# Altium schematic sheet Offset Compensator.SchDoc
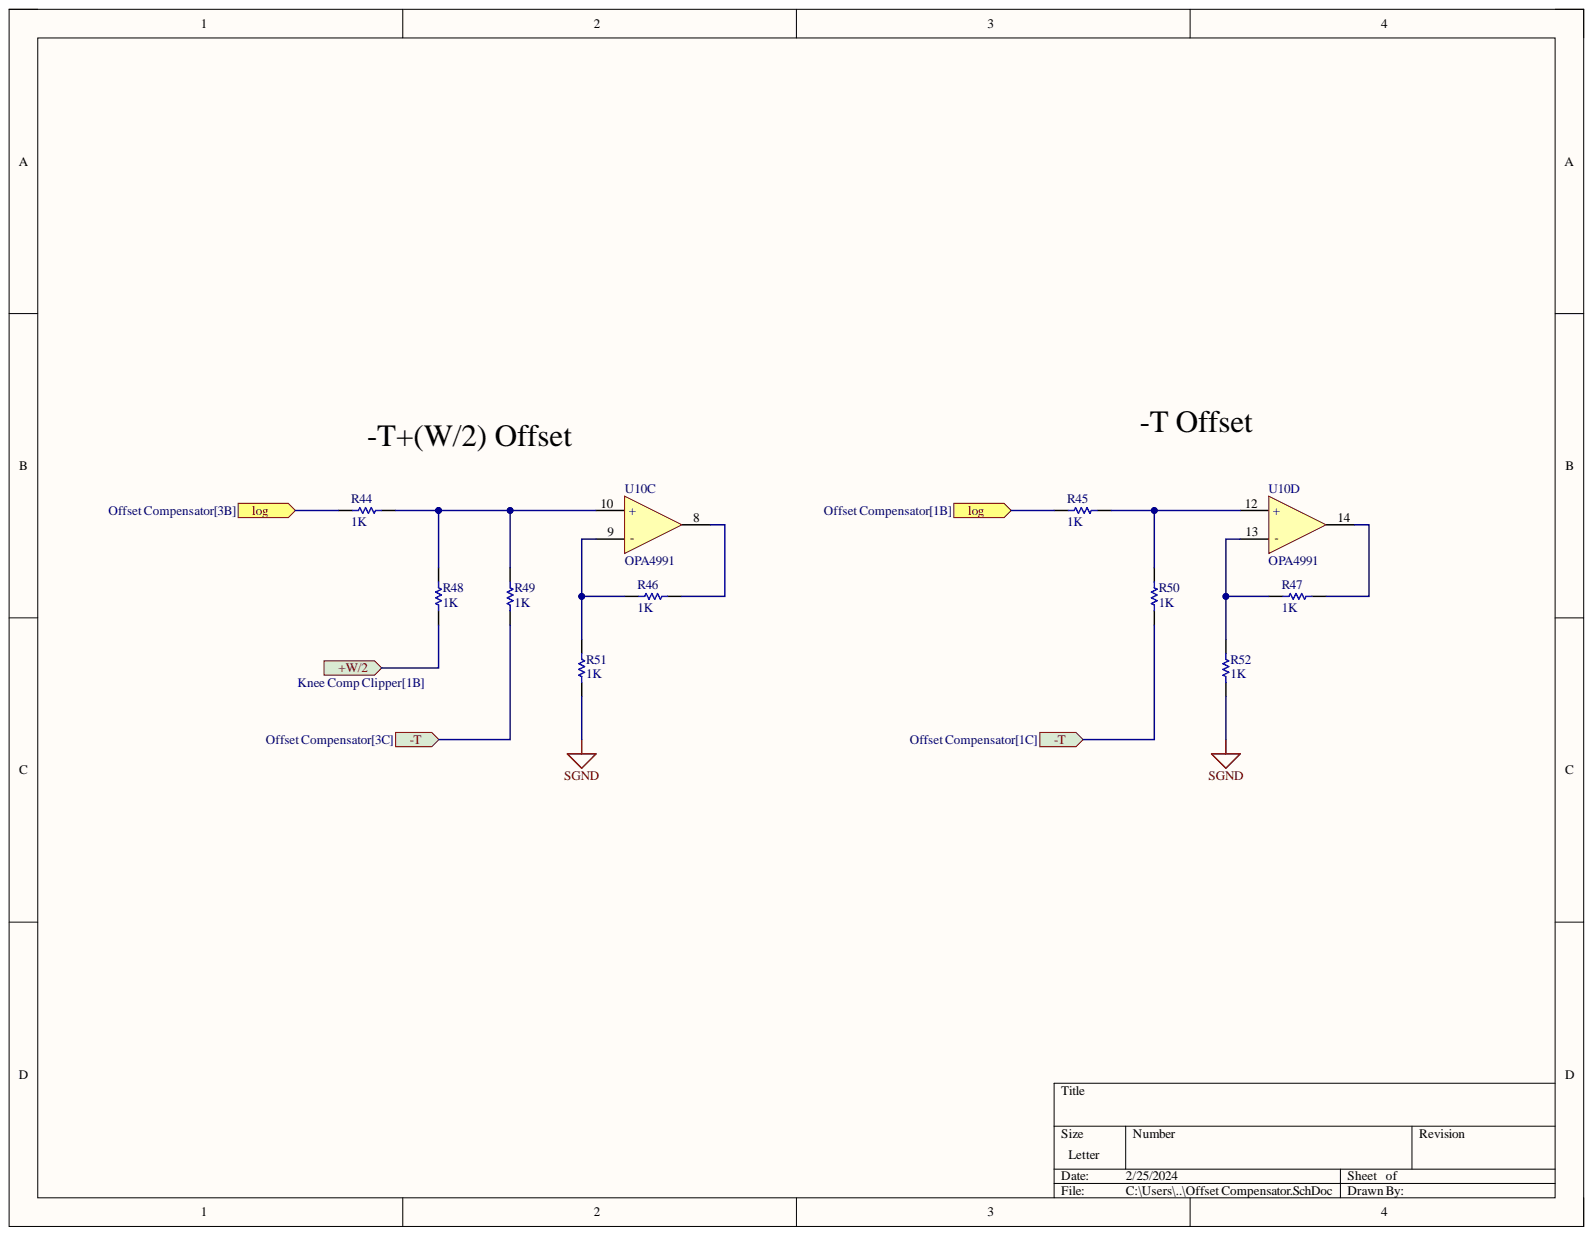
[diagram p0: Offset Compensator.SchDoc]

## Parts
R44  value 1K  (hidden comment: Resistor)
R45  value 1K  (hidden comment: Resistor)
R46  value 1K  (hidden comment: Resistor)
R47  value 1K  (hidden comment: Resistor)
R48  value 1K  (hidden comment: Resistor)
R49  value 1K  (hidden comment: Resistor)
R50  value 1K  (hidden comment: Resistor)
R51  value 1K  (hidden comment: Resistor)
R52  value 1K  (hidden comment: Resistor)
U10  OPA4991  [FP-D0014A-IPC_B]  (parts C,D)

## Ports
+W/2, -T, log

## Nets
SGND: R51.2 R52.2
+W/2: R48.1 ; port +W/2
-T: R49.1 R50.1 ; port -T
log: R44.1 R45.1 ; port log
NetR44_2: R44.2 R48.2 R49.2 U10.10(+)
NetR45_2: R45.2 R50.2 U10.12(+)
NetR46_1: R46.1 U10.8
NetR46_2: R46.2 R51.1 U10.9(-)
NetR47_1: R47.1 U10.14
NetR47_2: R47.2 R52.1 U10.13(-)

## Library data of the parts
R44-R52 = Resistor: SimulationGenericComponent: True; TC1: 0; Component Kind: Standard; Description: Resistor; Library Name: Simulation Generic Components.IntLib; Simulation: R_Resistor_Default; TC2: 0
U10 = OPA4991IDR: 40-V, DUAL 4.5MHZ, RAIL-TO-RAIL; Component Kind: Standard; Library Name: DRC.SchLib
